# Altium schematic sheet 06_LED_ARRAY.SchDoc(06_LED_ARRAY)
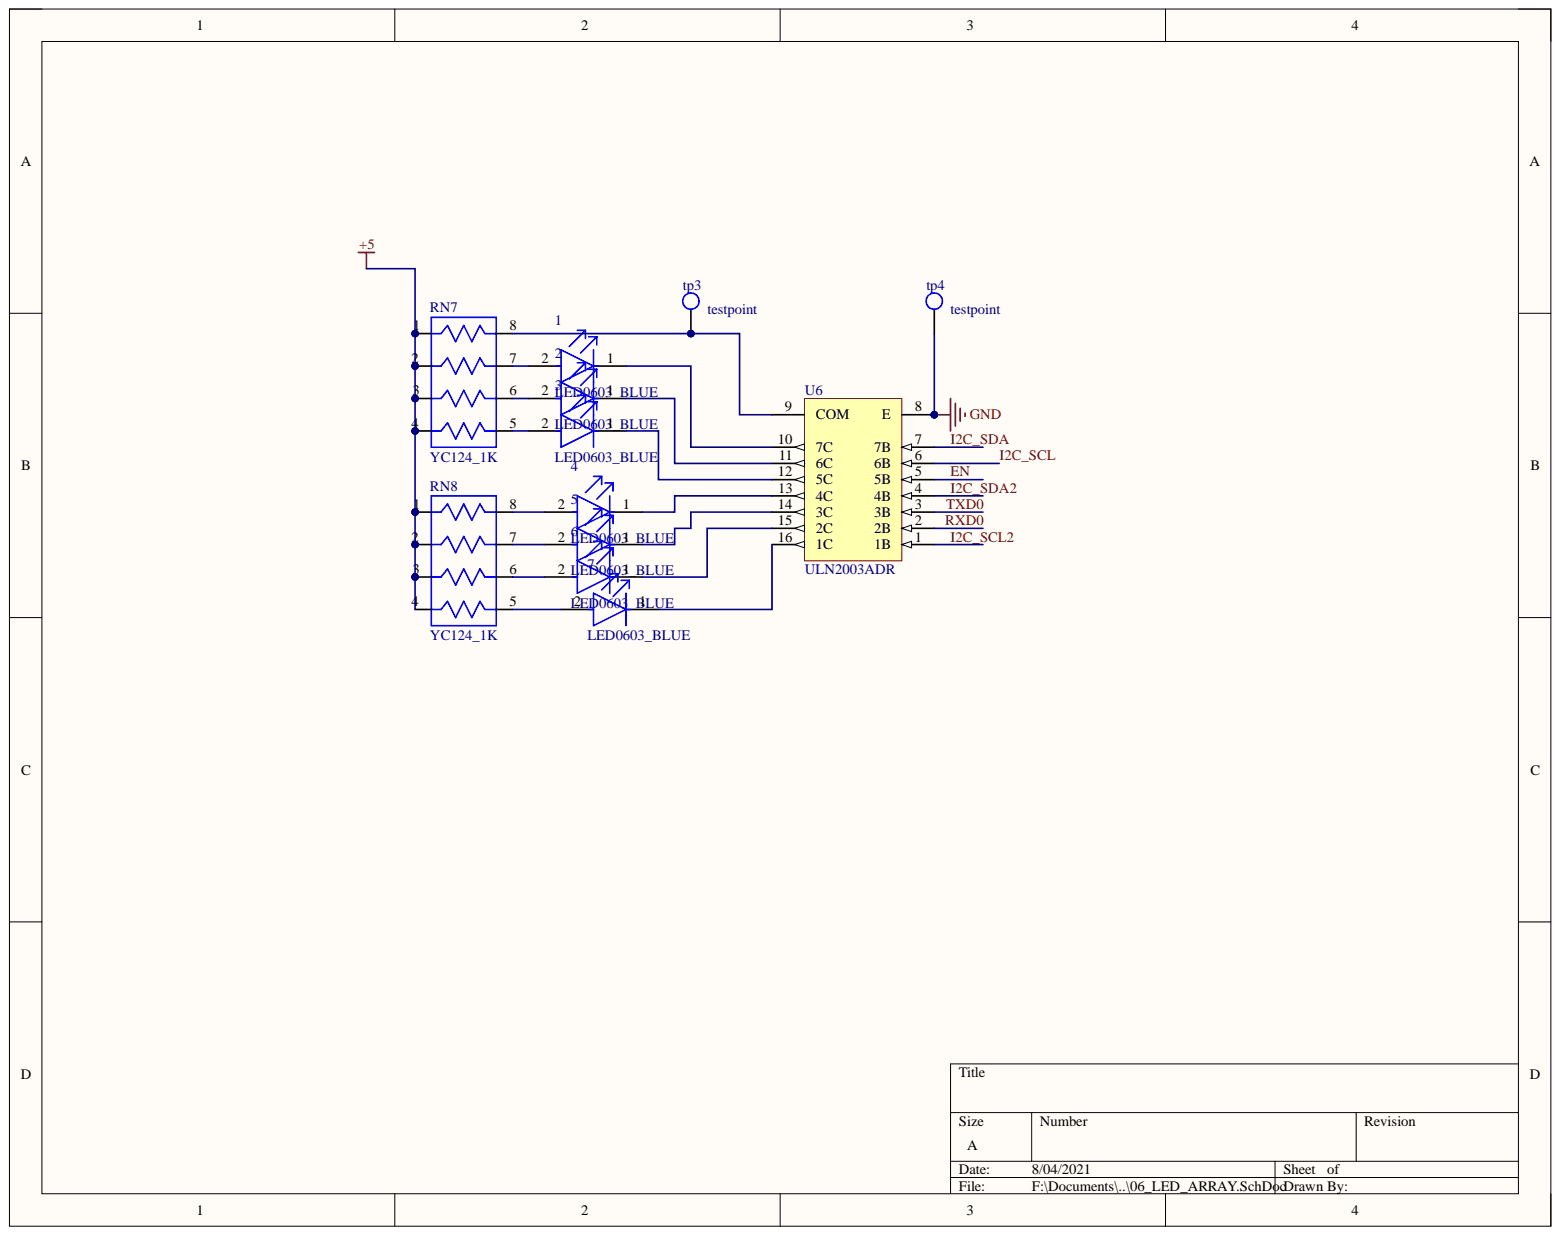
[diagram p0: 06_LED_ARRAY.SchDoc]

## Parts
1  LED0603_BLUE  [FP-LTST-C191TBKT-MFG]
2  LED0603_BLUE  [FP-LTST-C191TBKT-MFG]
3  LED0603_BLUE  [FP-LTST-C191TBKT-MFG]
4  LED0603_BLUE  [FP-LTST-C191TBKT-MFG]
5  LED0603_BLUE  [FP-LTST-C191TBKT-MFG]
6  LED0603_BLUE  [FP-LTST-C191TBKT-MFG]
7  LED0603_BLUE  [FP-LTST-C191TBKT-MFG]
RN7  YC124_1K  [YC124]
RN8  YC124_1K  [YC124]
tp3  testpoint  [testpoint_1mm]
tp4  testpoint  [testpoint_1mm]
U6  ULN2003ADR  [D0016A_M]

## Nets
+5: RN7.1 RN7.2 RN7.3 RN7.4 RN8.1 RN8.2 RN8.3 RN8.4
GND: tp4.1 U6.8(E)
EN: U6.5(5B)
I2C_SCL: U6.6(6B)
I2C_SCL2: U6.1(1B)
I2C_SDA: U6.7(7B)
I2C_SDA2: U6.4(4B)
RXD0: U6.2(2B)
TXD0: U6.3(3B)
Net1_1: 1.1 U6.10(7C)
Net1_2: 1.2 RN7.7
Net2_1: 2.1 U6.11(6C)
Net2_2: 2.2 RN7.6
Net3_1: 3.1 U6.12(5C)
Net3_2: 3.2 RN7.5
Net4_1: 4.1 U6.13(4C)
Net4_2: 4.2 RN8.8
Net5_1: 5.1 U6.14(3C)
Net5_2: 5.2 RN8.7
Net6_1: 6.1 U6.15(2C)
Net6_2: 6.2 RN8.6
Net7_1: 7.1 U6.16(1C)
Net7_2: 7.2 RN8.5
NetRN7_8: RN7.8 tp3.1 U6.9(COM)

## Library data of the parts
1-7 = CMP-22017-000008-1: LED BLUE CLEAR CHIP SMD; Dominant Wavelength: 475nm; Forward Current: 20mA; Forward Voltage: 3.8V; Lens Color: Colorless; Lens Style: Diffused; Luminous Intensity: 180mcd; Max Power Dissipation: 75mW; Number of Elements: 1; Power Dissipation: 76mW; Reverse Voltage: 5V; Viewing Angle: 130°; Wavelength: 468nm; Case Code (Imperial): 0603; Case Code (Metric): 1608; Case/Package: 0603; Color: Blue; Component Kind: Standard; Depth: 1.6mm; Height: 0.55mm; Illumination Color: Blue; Lead Free: Lead Free; Length: 0.8mm; Lens Transparency: Clear; Library Name: Altium Content Vault; Max Operating Temperature: 80°C; Min Operating Temperature: -20°C; Mount: Surface Mount; Number of LEDs: 1; Number of Pins: 2; Package Quantity: 1; Packaging: Tape and Reel; Radiation Hardening: No; Test Current: 20mA; Width: 0.8mm
RN7 RN8 = YC124: Component Kind: Standard; Library Name: RBS_library.IntLib
U6 = CMP-2000-04953-1: High-Voltage, High-Current Darlington Transistor Array, 0.5 A, 50 V, -20 to 70 degC, 16-Pin SOIC (D), Green (RoHS & no Sb/Br), Tape and Reel; Manufacturer: TexasInstruments; Configuration: Array7; Maximum Collector Emitter Saturation Voltage: 1.1@100mA; Maximum Collector Emitter Voltage: 50V; Package Version: 4040047-6/M, 06/2011; Peak DC Collector Current: 0.5A; Category: DarlingtonBJT; Component Kind: Standard; Datasheet URL: http://www.ti.com/general/docs/lit/getliterature.tsp?genericPartNumber=uln2003a&fileType=pdf; Datasheet Version: Rev. O, 01/2016; Library Name: Altium Content Vault; Manufacturer URL: http://www.ti.com/; Mounting: SurfaceMount; Package: 16SOIC; Package Description: 16-Pin Plastic Small Outline, Body 9.9 x 3.9 mm, Pitch 1.27 mm; Package Reference: D16; Package URL: http://www.ti.com/lit/ml/mpds178g/mpds178g.pdf; Packaging: Tape&Reel; Rad Hard: No; Type: NPN
tp3 tp4 = testpoint: Component Kind: Standard; Library Name: RBS_library.IntLib
